# Altium schematic sheet 2-Display.SchDoc(2-Display)
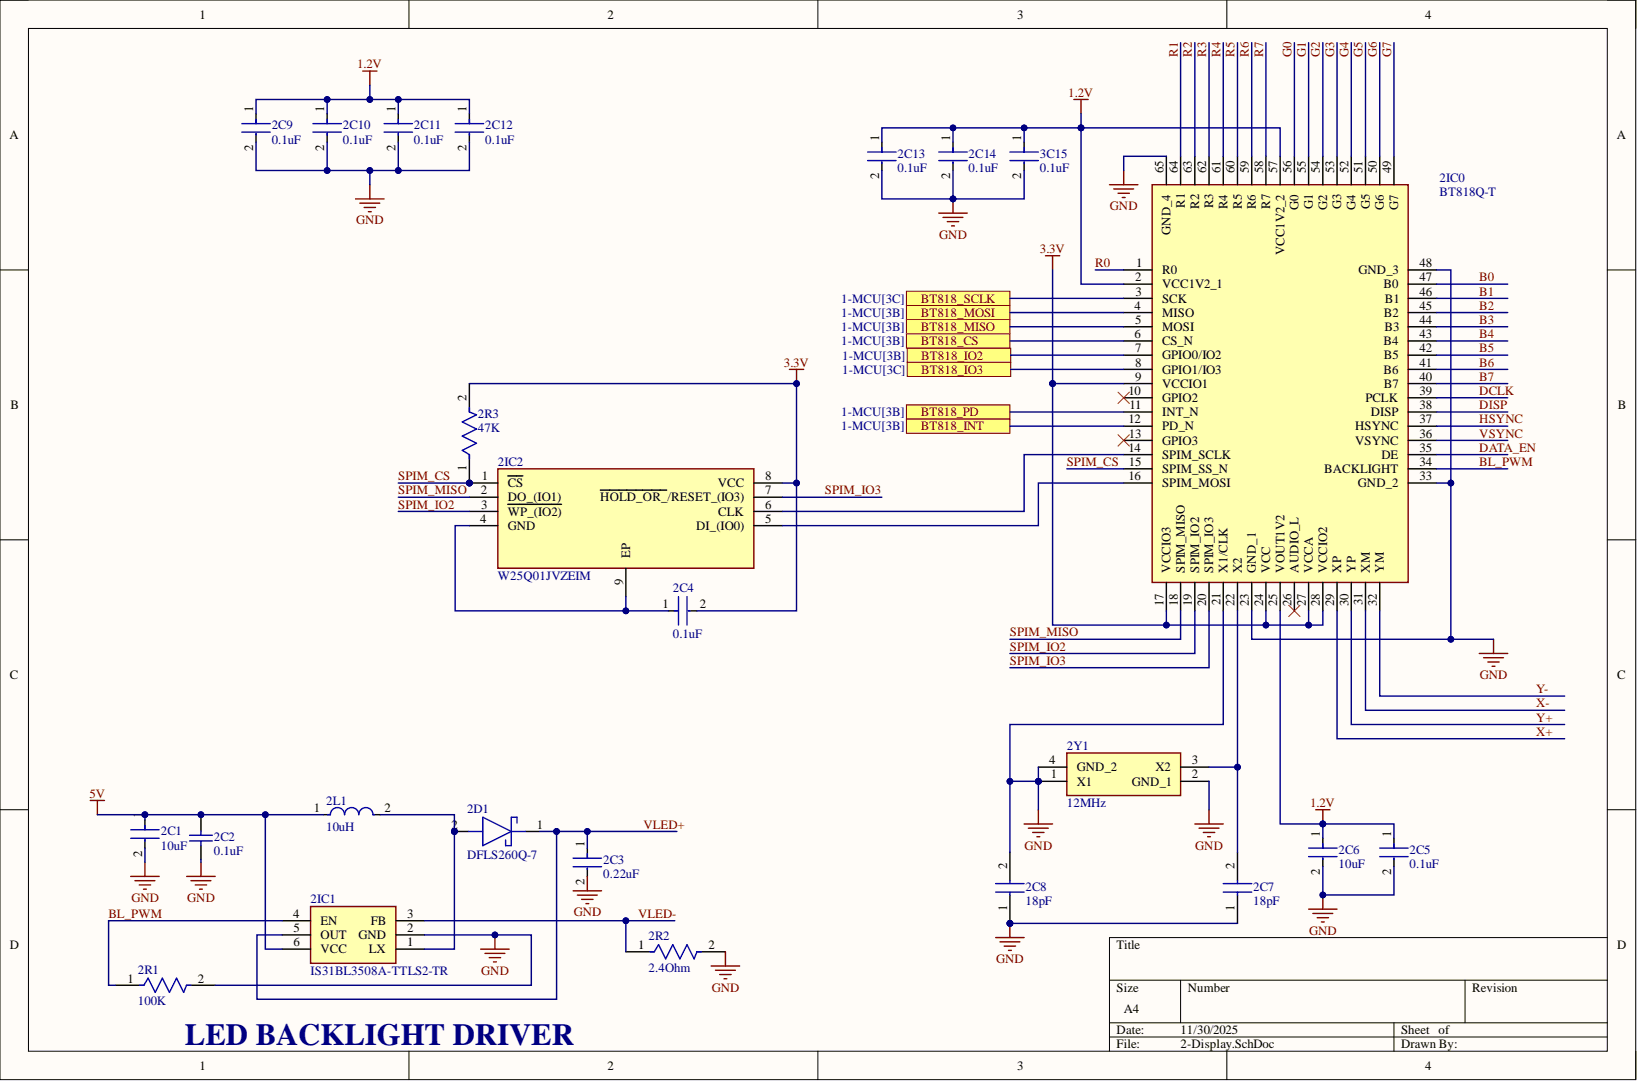
[diagram p0: 2-Display.SchDoc]

## Parts
2C1  10uF
2C2  0.1uF
2C3  0.22uF
2C4  0.1uF
2C5  0.1uF
2C6  10uF
2C7  18pF
2C8  18pF
2C9  0.1uF
2C10  0.1uF
2C11  0.1uF
2C12  0.1uF
2C13  0.1uF
2C14  0.1uF
2D1  DFLS260Q-7
2IC0  BT818Q-T
2IC1  IS31BL3508A-TTLS2-TR
2IC2  W25Q01JVZEIM
2J1  505110-4091  (value not drawn)
2L1  10uH
2R1  100K
2R2  2.4Ohm
2R3  47K
2Y1  12MHz
3C15  0.1uF

## Ports
BT818_CS, BT818_INT, BT818_IO2, BT818_IO3, BT818_MISO, BT818_MOSI, BT818_PD, BT818_SCLK

## Nets
1.2V: 2C5.1 2C6.1 2C9.1 2C10.1 2C11.1 2C12.1 2C13.1 2C14.1 2IC0.2(VCC1V2_1) 2IC0.25(VOUT1V2) 2IC0.57(VCC1V2_2) 3C15.1
3.3V: 2C4.2 2IC0.9(VCCIO1) 2IC0.17(VCCIO3) 2IC0.24(VCC) 2IC0.27(VCCA) 2IC0.28(VCCIO2) 2IC2.8(VCC) 2R3.2
5V: 2C1.1 2C2.2 2IC1.6(VCC) 2J1.4 2L1.1
B0: 2IC0.47(B0) 2J1.21
B1: 2IC0.46(B1) 2J1.22
B2: 2IC0.45(B2) 2J1.23
B3: 2IC0.44(B3) 2J1.24
B4: 2IC0.43(B4) 2J1.25
B5: 2IC0.42(B5) 2J1.26
B6: 2IC0.41(B6) 2J1.27
B7: 2IC0.40(B7) 2J1.28
BL_PWM: 2IC0.34(BACKLIGHT) 2IC1.4(EN) 2R1.1
BT818_CS: 2IC0.6(CS_N) ; port BT818_CS
BT818_INT: 2IC0.12(PD_N) ; port BT818_INT
BT818_IO2: 2IC0.7(GPIO0/IO2) ; port BT818_IO2
BT818_IO3: 2IC0.8(GPIO1/IO3) ; port BT818_IO3
BT818_MISO: 2IC0.5(MOSI) ; port BT818_MISO
BT818_MOSI: 2IC0.4(MISO) ; port BT818_MOSI
BT818_PD: 2IC0.11(INT_N) ; port BT818_PD
BT818_SCLK: 2IC0.3(SCK) ; port BT818_SCLK
DATA_EN: 2IC0.35(DE) 2J1.34
DCLK: 2IC0.39(PCLK) 2J1.31
DISP: 2IC0.38(DISP) 2J1.30
G0: 2IC0.56(G0) 2J1.13
G1: 2IC0.55(G1) 2J1.14
G2: 2IC0.54(G2) 2J1.15
G3: 2IC0.53(G3) 2J1.16
G4: 2IC0.52(G4) 2J1.17
G5: 2IC0.51(G5) 2J1.18
G6: 2IC0.50(G6) 2J1.19
G7: 2IC0.49(G7) 2J1.20
GND: 2C1.2 2C2.1 2C3.2 2C5.2 2C6.2 2C7.1 2C8.1 2C8.2 2C9.2 2C10.2 2C11.2 2C12.2 2C13.2 2C14.2 2IC0.21(X1/CLK) 2IC0.23(GND_1) 2IC0.33(GND_2) 2IC0.48(GND_3) 2IC0.65(GND_4) 2IC1.2(GND) 2J1.3 2J1.29 2J1.36 2R1.2 2R2.2 2Y1.1(X1) 2Y1.2(GND_1) 2Y1.4(GND_2) 3C15.2
HSYNC: 2IC0.37(HSYNC) 2J1.32
R0: 2IC0.1(R0) 2J1.5
R1: 2IC0.64(R1) 2J1.6
R2: 2IC0.63(R2) 2J1.7
R3: 2IC0.62(R3) 2J1.8
R4: 2IC0.61(R4) 2J1.9
R5: 2IC0.60(R5) 2J1.10
R6: 2IC0.59(R6) 2J1.11
R7: 2IC0.58(R7) 2J1.12
SPIM_CS: 2IC0.15(SPIM_SS_N) 2IC2.1(CS) 2R3.1
SPIM_IO2: 2IC0.19(SPIM_IO2) 2IC2.3(WP_(IO2))
SPIM_IO3: 2IC0.20(SPIM_IO3) 2IC2.7(HOLD_OR_/RESET_(IO3))
SPIM_MISO: 2IC0.18(SPIM_MISO) 2IC2.2(DO_(IO1))
VLED+: 2C3.1 2D1.1 2IC1.5(OUT) 2J1.2
VLED-: 2IC1.3(FB) 2J1.1 2R2.1
VSYNC: 2IC0.36(VSYNC) 2J1.33
X+: 2IC0.29(XP) 2J1.40
X-: 2IC0.31(XM) 2J1.38
Y+: 2IC0.30(YP) 2J1.37
Y-: 2IC0.32(YM) 2J1.39
Net2C4_1: 2C4.1 2IC2.4(GND) 2IC2.9(EP)
Net2C7_2: 2C7.2 2IC0.22(X2) 2Y1.3(X2)
Net2D1_2: 2D1.2 2IC1.1(LX) 2L1.2
Net2IC0_14: 2IC0.14(SPIM_SCLK) 2IC2.6(CLK)
Net2IC0_16: 2IC0.16(SPIM_MOSI) 2IC2.5(DI_(IO0))

Not connected: 2IC0 10(GPIO2) 13(GPIO3) 26(AUDIO_L); 2J1 35 41 42

## Library data of the parts
2C1 = CMP-08246-002209-1: Cap Ceramic 10uF 10V X5R 20% Pad SMD 0805 +85°C Automotive T/R; Capacitance: 10uF; Case Code (Imperial): 0805; Case Code (Metric): 2012; Case/Package: 0805; Component Kind: Standard; Length: 0.079inch; Library Name: Altium Content Vault; Max Operating Temperature: 85°C; Min Operating Temperature: -55°C; Mount: Surface Mount; Package Quantity: 2000; Packaging: Tape and Reel; Ratings: AEC-Q200; Thickness: 0.057inch; Tolerance: 20%; Voltage Rating: 10V; Width: 0.049inch
2C2 = CMP-2007-04740-1: Manufacturer: AVX Interconnect / Elco; Part Number: 08051C104M4Z2A; Component Kind: Standard; Library Name: Altium Content Vault
2C3 = CMP-08246-000651-1: Cap Ceramic 220nF 25V X8R 10% Pad SMD 0805 +150°C Automotive T/R; Capacitance: 220nF; Case Code (Imperial): 0805; Case Code (Metric): 2012; Case/Package: 0805; Component Kind: Standard; Features: Soft Termination; Length: 0.079inch; Library Name: Altium Content Vault; Max Operating Temperature: 150°C; Min Operating Temperature: -55°C; Mount: Surface Mount; Packaging: Tape and Reel; Ratings: AEC-Q200; Thickness: 0.059inch; Tolerance: 10%; Voltage Rating: 25V; Width: 0.047inch
2C4 2C5 = CMP-08246-001135-1: CGA4J2X8R1H104K125AA - Cap Ceramic 100nF 50V X8R 10% Pad SMD 0805 +150°C Automotive T/R; Capacitance: 100nF; Case Code (Imperial): 0805; Case Code (Metric): 2012; Case/Package: 0805; Component Kind: Standard; Composition: Ceramic; Depth: 1.25mm; Dielectric: X8R; Features: High Temperature; Height: 1.25mm; Length: 2mm; Library Name: Altium Content Vault; Max Operating Temperature: 150°C; Min Operating Temperature: -55°C; Mount: Surface Mount; Package Quantity: 1; Packaging: Tape and Reel; Ratings: AEC-Q200; REACH SVHC: No SVHC; Termination: SMD/SMT; Thickness: 0.057inch; Tolerance: 10%; Voltage Rating: 50V; Voltage Rating (DC): 50V; Weight: 0.000194oz; Width: 0.049inch
2C6 = CMP-14477-000144-3: EMK212BB7106MG-T - Multilayer Ceramic Capacitor 10uF 16V X7R 20% SMD 0805 T/R; Capacitance: 10uF; Case Code (Imperial): 0805; Case Code (Metric): 2012; Case/Package: 0805; Component Kind: Standard; Dielectric: X7R; Halogen Free: Halogen Free; Height: 1.25mm; Length: 2mm; Library Name: Altium Content Vault; Max Operating Temperature: 125°C; Min Operating Temperature: -55°C; Mount: Surface Mount; Package Quantity: 3000; Packaging: Tape and Reel; Ratings: AEC-Q200; Resistor Type: Ceramic; RoHS Compliant: Yes; Termination: SMD/SMT; Thickness: 0.053inch; Tolerance: 20%; Voltage Rating: 16V; Voltage Rating (DC): 16V; Width: 0.049inch
2C7 2C8 = GCM1555C1H180JA16D: Manufacturer_Name: Murata Electronics; Arrow Part Number: GCM1555C1H180JA16D; Arrow Price/Stock: https://www.arrow.com/en/products/gcm1555c1h180ja16d/murata-manufacturing; Component Kind: Standard; Datasheet Link: https://search.murata.co.jp/Ceramy/image/img/A01X/G101/ENG/GCM1555C1H180JA16-01A.pdf; Description: GCM1555C1H180JA16D; Height: 0.55mm; Manufacturer_Part_Number: GCM1555C1H180JA16D; Mouser Part Number: 81-GCM1555C1H180JA6D; Mouser Price/Stock: https://www.mouser.co.uk/ProductDetail/Murata-Electronics/GCM1555C1H180JA16D?qs=jDWTHf1mkXbaTEL17L%2FL8A%3D%3D
2C9 2C10 = CMP-08246-001181-1: CGA2B3X5R1E104K050BB - Cap Ceramic 100nF 25V X5R 10% Pad SMD 0402 +85°C Automotive T/R; Capacitance: 100nF; Case Code (Imperial): 0402; Case Code (Metric): 1005; Case/Package: 0402; Component Kind: Standard; Dielectric: X5R; Height: 0.5mm; Lead Free: Lead Free; Length: 0.039inch; Library Name: Altium Content Vault; Max Operating Temperature: 85°C; Min Operating Temperature: -55°C; Mount: Surface Mount; Package Quantity: 10000; Packaging: Tape and Reel; Ratings: AEC-Q200; Thickness: 0.022inch; Tolerance: 10%; Voltage Rating: 25V; Voltage Rating (DC): 25V; Weight: 2.3E-05oz; Width: 0.02inch
2C11 = CMP-08246-001181-1: CCGA2B3X5R1E104K050BB - Cap Ceramic 100nF 25V X5R 10% Pad SMD 0402 +85°C Automotive T/R; Capacitance: 100nF; Case Code (Imperial): 0402; Case Code (Metric): 1005; Case/Package: 0402; Component Kind: Standard; Dielectric: X5R; Height: 0.5mm; Lead Free: Lead Free; Length: 0.039inch; Library Name: Altium Content Vault; Max Operating Temperature: 85°C; Min Operating Temperature: -55°C; Mount: Surface Mount; Package Quantity: 10000; Packaging: Tape and Reel; Ratings: AEC-Q200; Thickness: 0.022inch; Tolerance: 10%; Voltage Rating: 25V; Voltage Rating (DC): 25V; Weight: 2.3E-05oz; Width: 0.02inch
2C12-2C14 3C15 = CMP-08246-001181-1: CGA2B3X5R1E104K050BB- Cap Ceramic 100nF 25V X5R 10% Pad SMD 0402 +85°C Automotive T/R; Capacitance: 100nF; Case Code (Imperial): 0402; Case Code (Metric): 1005; Case/Package: 0402; Component Kind: Standard; Dielectric: X5R; Height: 0.5mm; Lead Free: Lead Free; Length: 0.039inch; Library Name: Altium Content Vault; Max Operating Temperature: 85°C; Min Operating Temperature: -55°C; Mount: Surface Mount; Package Quantity: 10000; Packaging: Tape and Reel; Ratings: AEC-Q200; Thickness: 0.022inch; Tolerance: 10%; Voltage Rating: 25V; Voltage Rating (DC): 25V; Weight: 2.3E-05oz; Width: 0.02inch
2D1 = DFLS260Q-7: Schottky Diode; Manufacturer_Name: Diodes Incorporated; Arrow Part Number: DFLS260Q-7; Arrow Price/Stock: https://www.arrow.com/en/products/dfls260q-7/diodes-incorporated?utm_currency=USD&region=nac; Component Kind: Standard; Datasheet Link: https://www.diodes.com//assets/Datasheets/DFLS260Q.pdf; Height: 1mm; Library Name: SamacSys.SchLib; Manufacturer_Part_Number: DFLS260Q-7; Mouser Part Number: 621-DFLS260Q7; Mouser Price/Stock: https://www.mouser.co.uk/ProductDetail/Diodes-Incorporated/DFLS260Q-7?qs=gAfoL%252BRo%2FrLEuk8jrQqWWA%3D%3D
2IC0 = BT818Q-T: Integrated Circuit; Manufacturer_Name: Bridgetek Pte Ltd.; Component Kind: Standard; Datasheet Link: https://componentsearchengine.com/Datasheets/2/BT818Q-T.pdf; Height: 0.9mm; Library Name: SamacSys.SchLib; Manufacturer_Part_Number: BT818Q-T; Mouser Part Number: 895-BT818Q-T; Mouser Price/Stock: https://www.mouser.co.uk/ProductDetail/Bridgetek/BT818Q-T?qs=DPoM0jnrROVBAl%2FISC0Fog%3D%3D
2IC1 = IS31BL3506A-TTLS2-TR: Integrated Circuit; Manufacturer_Name: Lumissil Microsystems; Arrow Part Number: IS31BL3506A-TTLS2-TR; Arrow Price/Stock: https://www.arrow.com/en/products/is31bl3506a-ttls2-tr/integrated-silicon-solution-inc; Component Kind: Standard; Datasheet Link: https://datasheet.datasheetarchive.com/originals/distributors/Datasheets-DGA14/620159.pdf; Height: 0.9mm; Library Name: SamacSys.SchLib; Manufacturer_Part_Number: IS31BL3506A-TTLS2-TR; Mouser Part Number: 870-31BL3506ATTLS2TR; Mouser Price/Stock: https://www.mouser.com/Search/Refine.aspx?Keyword=870-31BL3506ATTLS2TR
2IC2 = W25Q01JVZEIM: Integrated Circuit; Manufacturer_Name: Winbond; Arrow Part Number: W25Q01JVZEIM; Arrow Price/Stock: https://www.arrow.com/en/products/w25q01jvzeim/winbond-electronics?utm_currency=USD&region=nac; Component Kind: Standard; Datasheet Link: https://www.mouser.es/datasheet/2/949/W25Q01JV_SPI_RevB_11132019-1760416.pdf; Height: 0.8mm; Manufacturer_Part_Number: W25Q01JVZEIM; Mouser Part Number: 454-W25Q01JVZEIM; Mouser Price/Stock: https://www.mouser.co.uk/ProductDetail/Winbond/W25Q01JVZEIM?qs=YwPsRIUVAOcrKxydcEklzg%3D%3D
2J1 = CMP-04775-000011-1: CONN FFC/FPC BOTTOM 40P .5MM R/A; Actuator Color: Black; Actuator Material: Nylon; Current Rating: 500mA; Gender: Receptacle; Mating Cycles: 25; Number of Circuits: 40; Pitch: 0.5mm; Voltage Rating: 50V; Component Kind: Standard; Contact Material: Bronze; Contact Plating: Gold; Flammability Rating: UL94 V-0; Halogen Free: Low Halogen; Height: 1.9mm; Housing Color: Beige; Housing Material: Polymer; Library Name: Altium Content Vault; Max Operating Temperature: 105°C; Min Operating Temperature: -40°C; Mount: Surface Mount; Number of Contacts: 40; Number of Pins: 40; Number of Positions: 40; Number of Rows: 1; Orientation: Right Angle; Packaging: Cut Tape; Series: Easy-On 505110; Termination: Solder
2L1 = CMP-14484-001358-1: Inductor Power Shielded Wirewound 10uH 20% 100KHz Ferrite 1A 0.324Ω DCR Automotive T/R; Current Rating: 1.1A; DC Resistance (DCR): 324mR; Self Resonant Frequency: 25MHz; Shielding: Unshielded; Case/Package: Nonstandard; Component Kind: Standard; Core Material: Ferrite; Depth: 6mm; Halogen Free: Halogen Free; Height: 1mm; Height - Seated (Max): 0.039inch; Inductance: 10uH; Length: 0.236inch; Library Name: Altium Content Vault; Max DC Current: 1.1A; Max Operating Temperature: 125°C; Min Operating Temperature: -40°C; Mount: Surface Mount; Package Quantity: 1000; Packaging: Tape and Reel; Radiation Hardening: No; Ratings: AEC-Q200; Resistor Type: Wirewound; RoHS Compliant: Yes; Saturation Current: 1A; Termination: SMD/SMT; Test Frequency: 100kHz; Tolerance: 20%; Width: 0.236inch
2R1 = CMP-03412-042028-1: Thin Film Chip Resistors Automotive Grade 100kΩ 0.5% 25ppm/°C 0805; Max Power Dissipation: 125mW; Resistance: 100kR; Case Code (Imperial): 0805; Case Code (Metric): 2012; Case/Package: 0805; Component Kind: Standard; Features: Moisture Resistant; Height: 0.024inch; Length: 0.079inch; Library Name: Altium Content Vault; Max Operating Temperature: 155°C; Min Operating Temperature: -55°C; Number of Terminations: 2; Packaging: Cut Tape; Resistor Type: Thin Film; RoHS Compliant: Yes; Series: AT; Temperature Coefficient: 25ppm/°C; Tolerance: 0.5%; Width: 0.049inch
2R2 = CMP-08839-028865-1: 2.4Ω ±5% 0.25W 0603 Anti-Surge Chip Resistor AEC-Q200; Max Power Dissipation: 250mW; Resistance: 2.4R; Temperature Coefficient: 200ppm/°C; Case Code (Imperial): 0603; Case Code (Metric): 1608; Case/Package: 0603; Component Kind: Standard; Features: Automotive AEC-Q200; Height: 0.022inch; Length: 0.063inch; Library Name: Altium Content Vault; Max Operating Temperature: 155°C; Min Operating Temperature: -55°C; Number of Terminations: 2; Package Quantity: 5000; Packaging: Cut Tape; Resistor Type: Thick Film; Series: ESR; Tolerance: 5%; Width: 0.031inch
2R3 = CMP-02407-012789-1: CRCW080547K0FKEC RES Thick Film, 47kΩ, 1%, 0.125W, 100ppm/°C, 0805; Max Power Dissipation: 125mW; Resistance: 47kR; Case/Package: 0805; Component Kind: Standard; Depth: 1.25mm; Features: Automotive AEC-Q200; Height: 0.02inch; Lead Free: Lead Free; Length: 0.079inch; Library Name: Altium Content Vault; Max Operating Temperature: 155°C; Military Standard: Not; Min Operating Temperature: -55°C; Number of Terminations: 2; Package Quantity: 20000; Packaging: Tape and Reel; Resistor Type: Thick Film; RoHS Compliant: Yes; Series: CRCW; Temperature Coefficient: 100ppm/°C; Tolerance: 1%; Width: 0.049inch
2Y1 = ABM8AIG-12.000MHZ-12-2Z-T3: ABM8AIG-12.000MHZ-12-2Z-T3 Crystal or Oscillator; Manufacturer_Name: ABRACON; Arrow Part Number: ABM8AIG-12.000MHZ-12-2Z-T3; Arrow Price/Stock: https://www.arrow.com/en/products/abm8aig12.000mhz122zt3/abracon?region=nac; Component Kind: Standard; Datasheet Link: https://abracon.com/AIGcrystals/ABM8AIG.pdf; Height: 0.8mm; Manufacturer_Part_Number: ABM8AIG-12.000MHZ-12-2Z-T3; Mouser Part Number: 815-M8AIG-12-12-2ZT3; Mouser Price/Stock: https://www.mouser.co.uk/ProductDetail/ABRACON/ABM8AIG-12.000MHZ-12-2Z-T3?qs=gG6m684uYP5EjaQyIprz9g%3D%3D
